# Altium schematic sheet Spectre-SCH_SH2_(rev).SchDoc
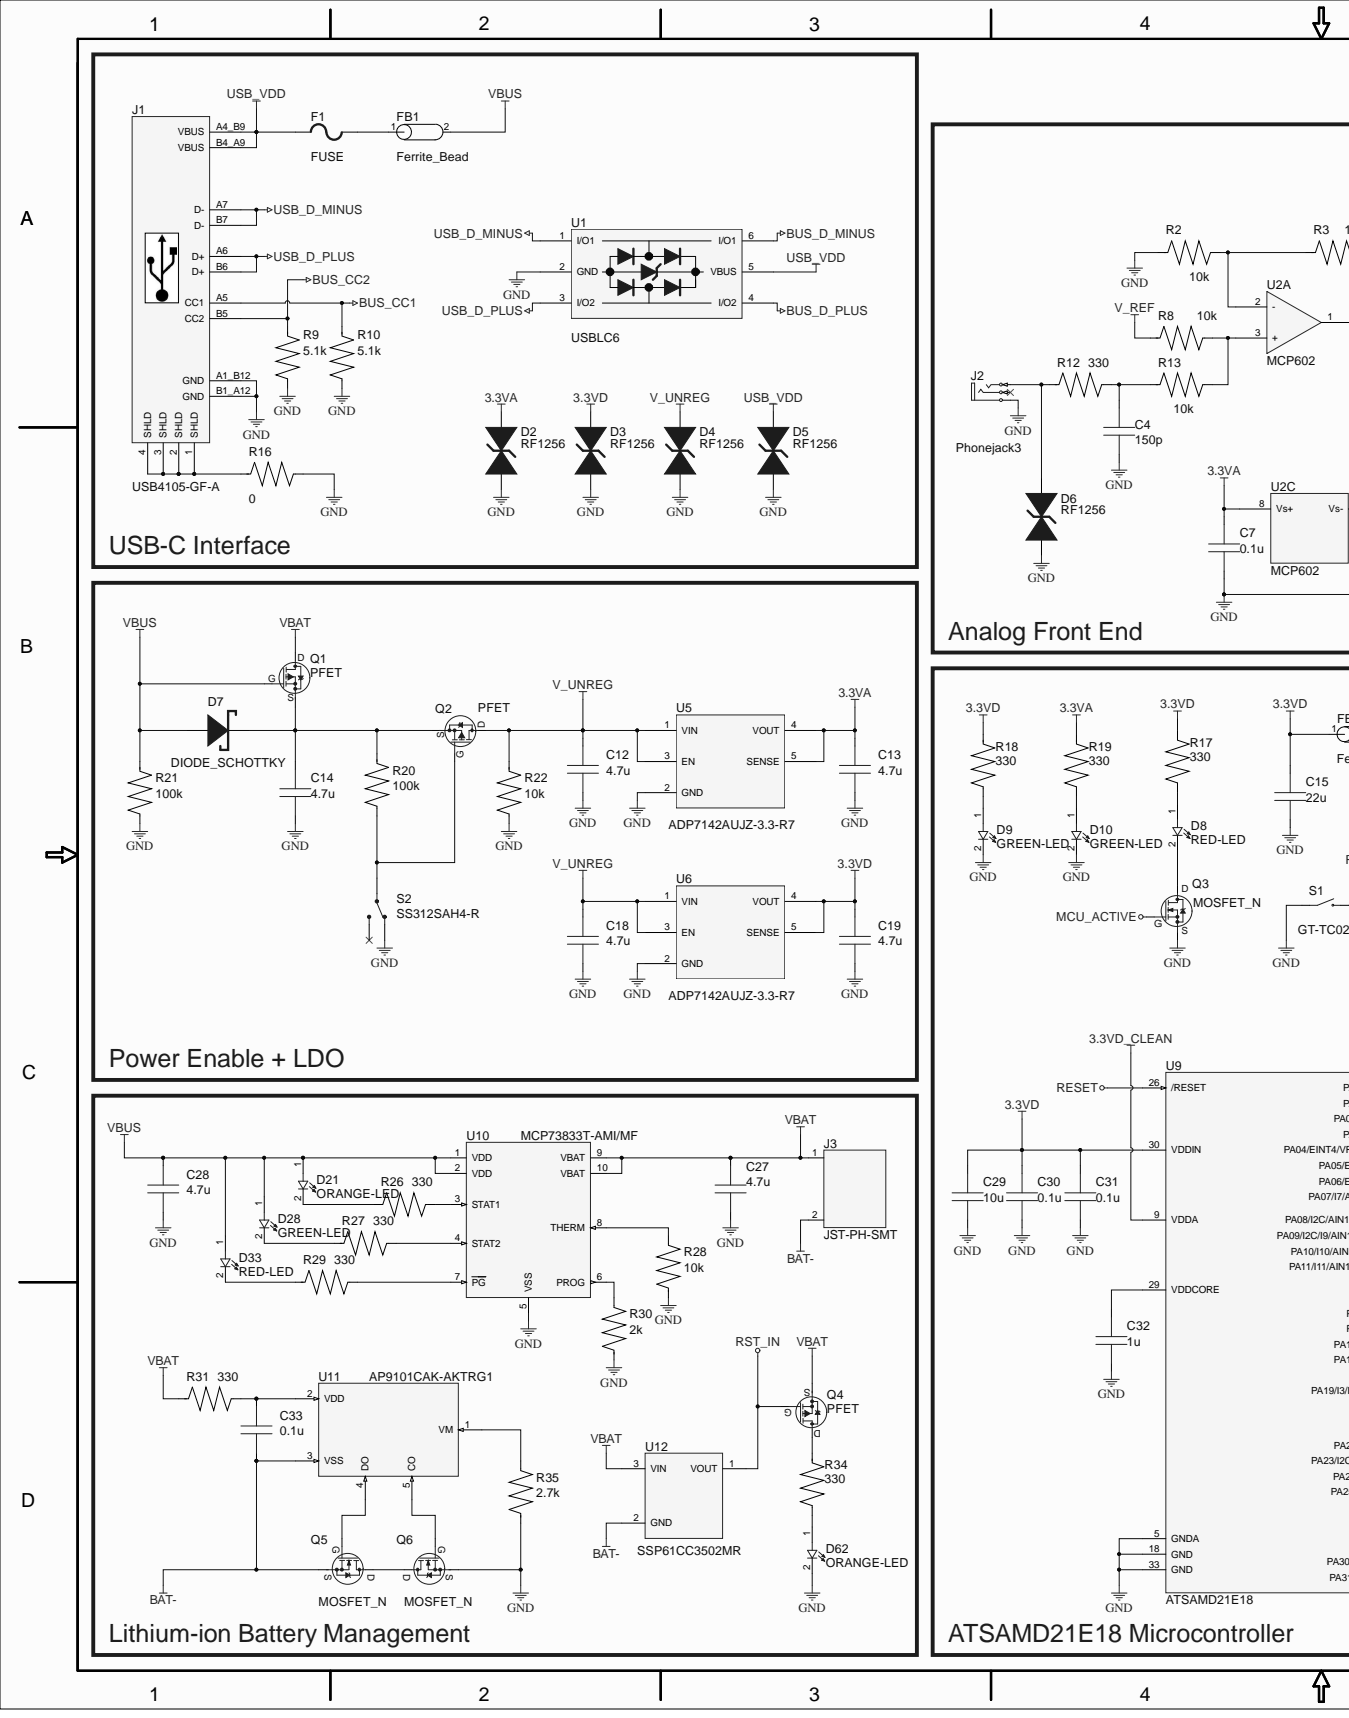
[diagram p0: Spectre-SCH_SH2_(rev).SchDoc, left]
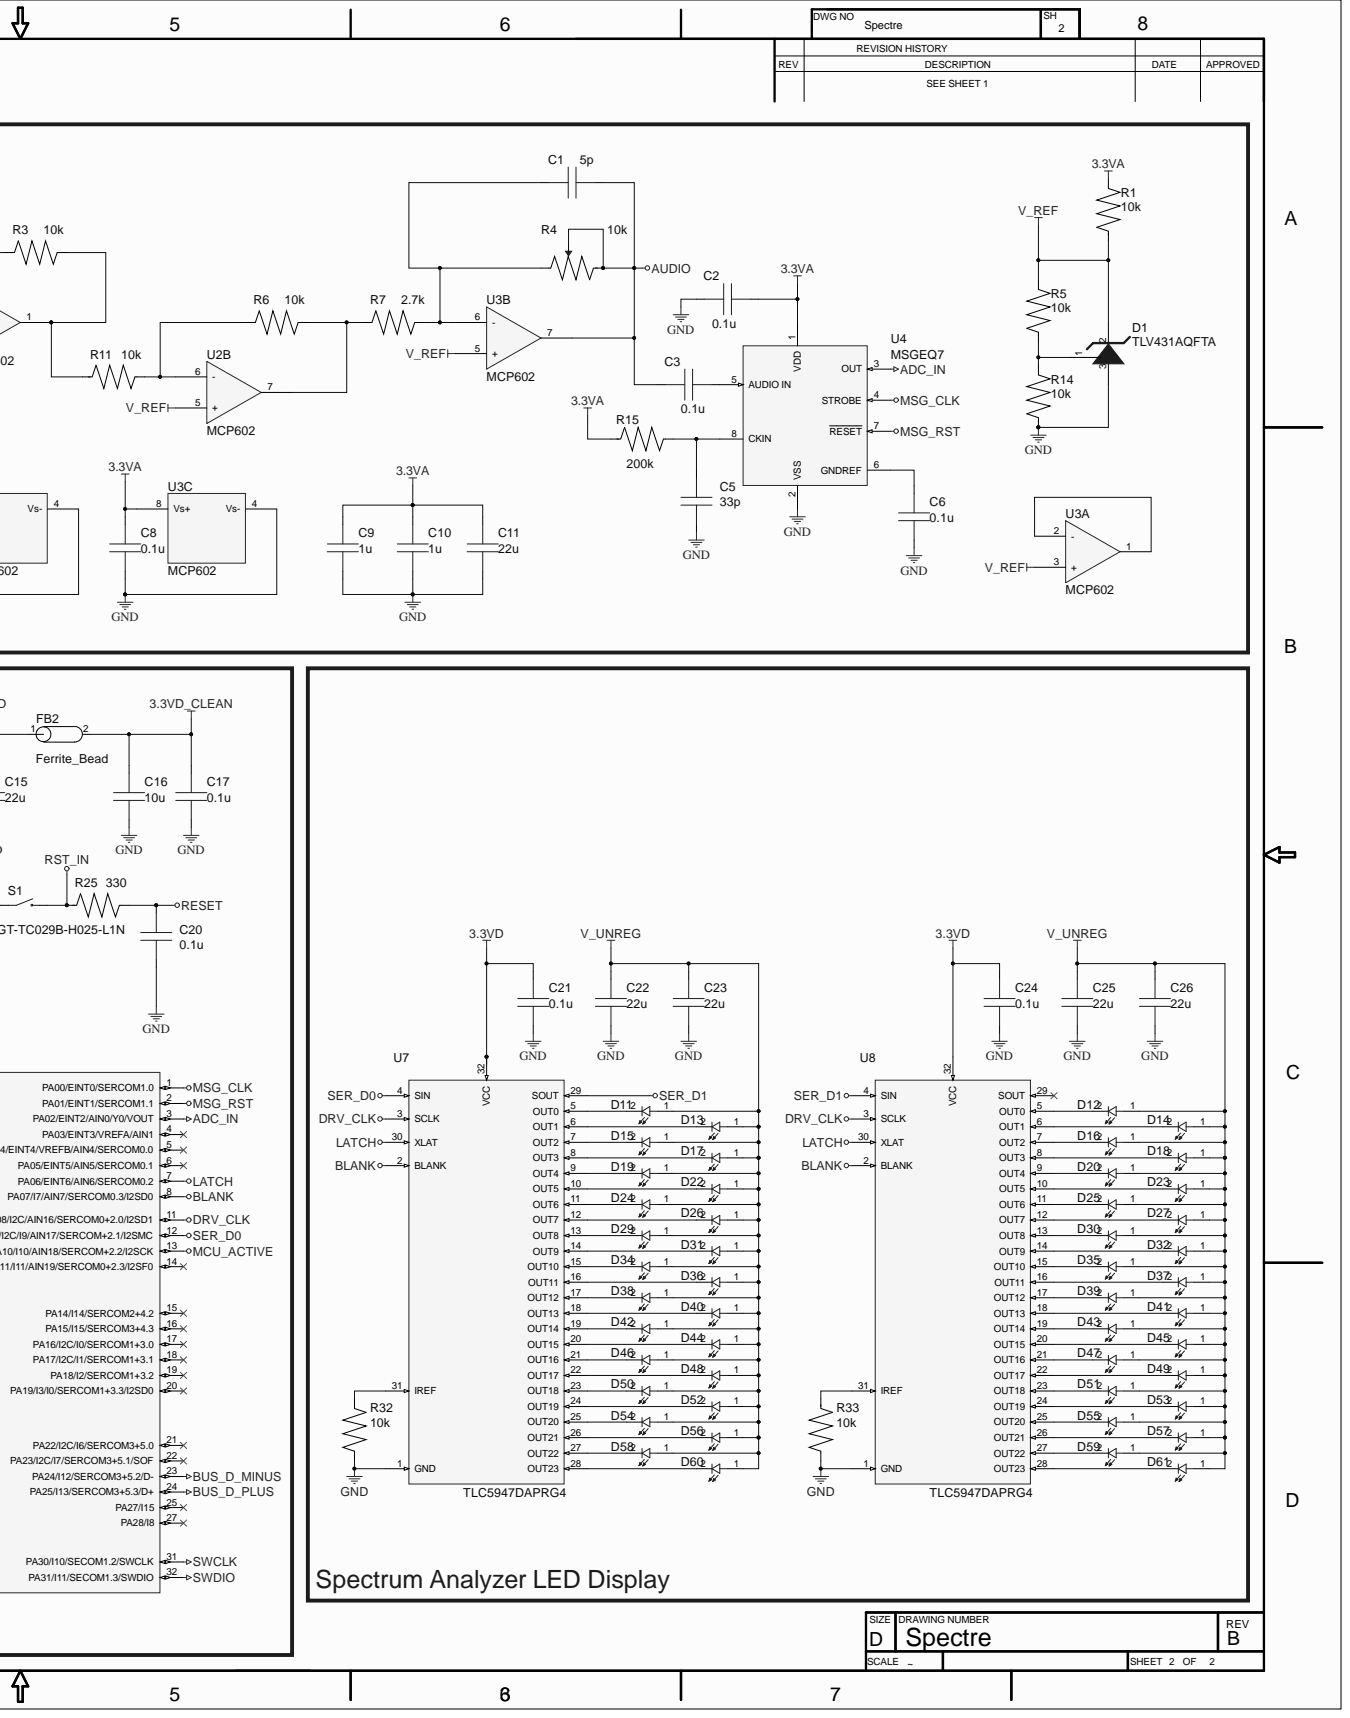
[diagram p1: Spectre-SCH_SH2_(rev).SchDoc, right]

## Parts
C1  5p  [0603]
C2  0.1u  [0603]
C3  0.1u  [0603]
C4  150p  [0603]
C5  33p  [0603]
C6  0.1u  [0603]
C7  0.1u  [0603]
C8  0.1u  [0603]
C9  1u  [0603]
C10  1u  [0603]
C11  22u  [0603]
C12  4.7u  [0603]
C13  4.7u  [0603]
C14  4.7u  [0603]
C15  22u  [0603]
C16  10u  [0603]
C17  0.1u  [0603]
C18  4.7u  [0603]
C19  4.7u  [0603]
C20  0.1u  [0603]
C21  0.1u  [0603]
C22  22u  [0603]
C23  22u  [0603]
C24  0.1u  [0603]
C25  22u  [0603]
C26  22u  [0603]
C27  4.7u  [0603]
C28  4.7u  [0603]
C29  10u  [0603]
C30  0.1u  [0603]
C31  0.1u  [0603]
C32  1u  [0603]
C33  0.1u  [0603]
D1  TLV431AQFTA  [SOT-23-3]
D2  RF1256  [0603]
D3  RF1256  [0603]
D4  RF1256  [0603]
D5  RF1256  [0603]
D6  RF1256  [0603]
D7  DIODE_SCHOTTKY  [SMA]
D8  RED-LED  [0603 LED]
D9  GREEN-LED  [0603 LED]
D10  GREEN-LED  [0603 LED]
D11  RED-LED  [0603 LED]  (value not drawn)
D12  RED-LED  [0603 LED]  (value not drawn)
D13  ORANGE-LED  [0603 LED]
D14  ORANGE-LED  [0603 LED]
D15  YELLOW-LED  [0603 LED]  (value not drawn)
D16  YELLOW-LED  [0603 LED]  (value not drawn)
D17  YELLOW-LED  [0603 LED]  (value not drawn)
D18  YELLOW-LED  [0603 LED]  (value not drawn)
D19  GREEN-LED  [0603 LED]
D20  GREEN-LED  [0603 LED]
D21  ORANGE-LED  [0603 LED]
D22  GREEN-LED  [0603 LED]
D23  GREEN-LED  [0603 LED]
D24  RED-LED  [0603 LED]  (value not drawn)
D25  RED-LED  [0603 LED]  (value not drawn)
D26  ORANGE-LED  [0603 LED]
D27  ORANGE-LED  [0603 LED]
D28  GREEN-LED  [0603 LED]
D29  YELLOW-LED  [0603 LED]  (value not drawn)
D30  YELLOW-LED  [0603 LED]  (value not drawn)
D31  YELLOW-LED  [0603 LED]  (value not drawn)
D32  YELLOW-LED  [0603 LED]  (value not drawn)
D33  RED-LED  [0603 LED]
D34  GREEN-LED  [0603 LED]
D35  GREEN-LED  [0603 LED]
D36  GREEN-LED  [0603 LED]
D37  GREEN-LED  [0603 LED]
D38  GREEN-LED  [0603 LED]
D39  RED-LED  [0603 LED]  (value not drawn)
D40  GREEN-LED  [0603 LED]
D41  ORANGE-LED  [0603 LED]
D42  YELLOW-LED  [0603 LED]  (value not drawn)
D43  YELLOW-LED  [0603 LED]  (value not drawn)
D44  YELLOW-LED  [0603 LED]  (value not drawn)
D45  YELLOW-LED  [0603 LED]  (value not drawn)
D46  ORANGE-LED  [0603 LED]
D47  GREEN-LED  [0603 LED]
D48  RED-LED  [0603 LED]  (value not drawn)
D49  GREEN-LED  [0603 LED]
D50  GREEN-LED  [0603 LED]
D51  GREEN-LED  [0603 LED]
D52  GREEN-LED  [0603 LED]
D53  GREEN-LED  [0603 LED]
D54  YELLOW-LED  [0603 LED]  (value not drawn)
D55  YELLOW-LED  [0603 LED]  (value not drawn)
D56  RED-LED  [0603 LED]  (value not drawn)
D57  YELLOW-LED  [0603 LED]  (value not drawn)
D58  ORANGE-LED  [0603 LED]
D59  ORANGE-LED  [0603 LED]
D60  YELLOW-LED  [0603 LED]  (value not drawn)
D61  RED-LED  [0603 LED]  (value not drawn)
D62  ORANGE-LED  [0603 LED]
F1  FUSE  [1206]
FB1  Ferrite_Bead  [0603]
FB2  Ferrite_Bead  [0603]
J1  USB4105-GF-A  [USB4105-GF-A]
J2  Phonejack3  [STX-3500-3NTR]
J3  JST-PH-SMT  [JST-PH-SMT]
Q1  PFET  [TO-252-3]
Q2  PFET  [TO-252-3]
Q3  MOSFET_N  [SOT-23]
Q4  PFET  [SOT-23]
Q5  MOSFET_N  [SOT-23]
Q6  MOSFET_N  [SOT-23]
R1  10k  [0603]
R2  10k  [0603]
R3  10k  [0603]
R4  10k  [ALPS 9mm]
R5  10k  [0603]
R6  10k  [0603]
R7  2.7k  [0603]
R8  10k  [0603]
R9  5.1k  [0603]
R10  5.1k  [0603]
R11  10k  [0603]
R12  330  [0603]
R13  10k  [0603]
R14  10k  [0603]
R15  200k  [0603]
R16  0  [0603]
R17  330  [0603]
R18  330  [0603]
R19  330  [0603]
R20  100k  [0603]
R21  100k  [0603]
R22  10k  [0603]
R25  330  [0603]
R26  330  [0603]
R27  330  [0603]
R28  10k  [0603]
R29  330  [0603]  (value not drawn)
R30  2k  [0603]
R31  330  [0603]
R32  10k  [0603]
R33  10k  [0603]
R34  330  [0603]
R35  2.7k  [0603]
S1  GT-TC029B-H025-L1N  [GT-TC029B-H025-L1N]
S2  SS312SAH4-R  [SW_SS312SAH4-R]
U1  USBLC6  [SOT-23-6_N]
U2  MCP602  [MSOP-8]  (parts A,B,C)
U3  MCP602  [MSOP-8]  (parts A,B,C)
U4  MSGEQ7  [MSGEQ7]
U5  ADP7142AUJZ-3.3-R7  [TSOT-23-5]
U6  ADP7142AUJZ-3.3-R7  [TSOT-23-5]
U7  TLC5947DAPRG4  [*SOP65P810X120-32N]
U8  TLC5947DAPRG4  [*SOP65P810X120-32N]
U9  ATSAMD21E18  [QFN-32 Handsolder]
U10  MCP73833T-AMI/MF  [MSOP-10]
U11  AP9101CAK-AKTRG1  [SOT-23-5]
U12  SSP61CC3502MR  [SOT-23-3]

## Nets
3.3VA: C2.1 C7.2 C8.2 C9.2 C10.2 C11.2 C13.2 D2.2 R1.1 R15.2 R19.2 U2.8(Vs+) U3.8(Vs+) U4.1(VDD) U5.4(VOUT) U5.5(SENSE)
3.3VD: C15.2 C19.2 C21.2 C24.2 C29.2 C30.2 C31.2 D3.2 FB2.1 R17.2 R18.2 U6.4(VOUT) U6.5(SENSE) U7.32(VCC) U8.32(VCC) U9.30(VDDIN)
3.3VD_CLEAN: C16.2 C17.2 FB2.2 U9.9(VDDA)
ADC_IN: U4.3(OUT) U9.3(PA02/EINT2/AIN0/Y0/VOUT)
AUDIO: C1.1 C3.2 R4.2 R4.3 U3.7
BAT-: C33.1 J3.2 Q5.3 U11.3(VSS) U12.2
BLANK: U7.2(BLANK) U8.2(BLANK) U9.8(PA07/I7/AIN7/SERCOM0.3/I2SD0)
BUS_CC1: J1.A5(CC1) R10.2
BUS_CC2: J1.B5(CC2) R9.2
BUS_D_MINUS: U1.6(I/O1) U9.23(PA24/I12/SERCOM3+5.2/D-)
BUS_D_PLUS: U1.4(I/O2) U9.24(PA25/I13/SERCOM3+5.3/D+)
DRV_CLK: U7.3(SCLK) U8.3(SCLK) U9.11(PA08/I2C/AIN16/SERCOM0+2.0/I2SD1)
GND: C2.2 C4.1 C5.2 C6.2 C7.1 C8.1 C9.1 C10.1 C11.1 C12.1 C13.1 C14.1 C15.1 C16.1 C17.1 C18.1 C19.1 C20.1 C21.1 C22.1 C23.1 C24.1 C25.1 C26.1 C27.1 C28.1 C29.1 C30.1 C31.1 C32.1 D1.3 D2.1 D3.1 D4.1 D5.1 D6.1 D9.2 D10.2 D62.2 J1.A1_B12(GND) J1.B1_A12(GND) J2.1 Q3.3 Q6.3 R2.2 R9.1 R10.1 R14.2 R16.1 R21.1 R22.1 R28.2 R30.2 R32.1 R33.1 R35.2 S1.1 S2.1 U1.2(GND) U2.4(Vs-) U3.4(Vs-) U4.2(VSS) U5.2(GND) U6.2(GND) U7.1(GND) U8.1(GND) U9.5 U9.18 U9.33(GND) U10.5(VSS)
LATCH: U7.30(XLAT) U8.30(XLAT) U9.7(PA06/EINT6/AIN6/SERCOM0.2)
MCU_ACTIVE: Q3.1 U9.13(PA10/I10/AIN18/SERCOM+2.2/I2SCK)
MSG_CLK: U4.4(STROBE) U9.1(PA00/EINT0/SERCOM1.0)
MSG_RST: U4.7(RESET) U9.2(PA01/EINT1/SERCOM1.1)
RESET: C20.2 R25.1 U9.26(/RESET)
RST_IN: Q4.1 R25.2 S1.2 U12.1
SER_D0: U7.4(SIN) U9.12(PA09/I2C/I9/AIN17/SERCOM+2.1/I2SMC)
SER_D1: U7.29(SOUT) U8.4(SIN)
SWCLK: U9.31(PA30/I10/SECOM1.2/SWCLK)
SWDIO: U9.32(PA31/I11/SECOM1.3/SWDIO)
USB_D_MINUS: J1.A7(D-) J1.B7(D-) U1.1(I/O1)
USB_D_PLUS: J1.A6(D+) J1.B6(D+) U1.3(I/O2)
USB_VDD: D5.2 F1.1 J1.A4_B9(VBUS) J1.B4_A9(VBUS) U1.5(VBUS)
V_REF: D1.2 R1.2 R5.1 R8.2 U2.5(+) U3.3(+) U3.5(+)
V_UNREG: C12.2 C18.2 C22.2 C23.2 C25.2 C26.2 D4.2 D11.1 D12.1 D13.1 D14.1 D15.1 D16.1 D17.1 D18.1 D19.1 D20.1 D22.1 D23.1 D24.1 D25.1 D26.1 D27.1 D29.1 D30.1 D31.1 D32.1 D34.1 D35.1 D36.1 D37.1 D38.1 D39.1 D40.1 D41.1 D42.1 D43.1 D44.1 D45.1 D46.1 D47.1 D48.1 D49.1 D50.1 D51.1 D52.1 D53.1 D54.1 D55.1 D56.1 D57.1 D58.1 D59.1 D60.1 D61.1 Q2.2 R22.2 U5.1(VIN) U5.3(EN) U6.1(VIN) U6.3(EN)
VBAT: C27.2 J3.1 Q1.2 Q4.3 R31.2 U10.9(VBAT) U10.10(VBAT) U12.3
VBUS: C28.2 D7.1 D21.1 D28.1 D33.1 FB1.2 Q1.1 R21.2 U10.1(VDD) U10.2(VDD)
NetC1_2: C1.2 R4.1 R7.1 U3.6(-)
NetC3_1: C3.1 U4.5(AUDIO_IN)
NetC4_2: C4.2 R12.1 R13.2
NetC5_1: C5.1 R15.1 U4.8(CKIN)
NetC6_1: C6.1 U4.6(GNDREF)
NetC14_2: C14.2 D7.2 Q1.3 Q2.3 R20.2
NetC32_2: C32.2 U9.29(VDDCORE)
NetC33_2: C33.2 R31.1 U11.2(VDD)
NetD1_1: D1.1 R5.2 R14.1
NetD6_2: D6.2 J2.3 R12.2
NetD8_1: D8.1 R17.1
NetD8_2: D8.2 Q3.2
NetD9_1: D9.1 R18.1
NetD10_1: D10.1 R19.1
NetD11_2: D11.2 U7.5(OUT0)
NetD12_2: D12.2 U8.5(OUT0)
NetD13_2: D13.2 U7.6(OUT1)
NetD14_2: D14.2 U8.6(OUT1)
NetD15_2: D15.2 U7.7(OUT2)
NetD16_2: D16.2 U8.7(OUT2)
NetD17_2: D17.2 U7.8(OUT3)
NetD18_2: D18.2 U8.8(OUT3)
NetD19_2: D19.2 U7.9(OUT4)
NetD20_2: D20.2 U8.9(OUT4)
NetD21_2: D21.2 R26.2
NetD22_2: D22.2 U7.10(OUT5)
NetD23_2: D23.2 U8.10(OUT5)
NetD24_2: D24.2 U7.11(OUT6)
NetD25_2: D25.2 U8.11(OUT6)
NetD26_2: D26.2 U7.12(OUT7)
NetD27_2: D27.2 U8.12(OUT7)
NetD28_2: D28.2 R27.2
NetD29_2: D29.2 U7.13(OUT8)
NetD30_2: D30.2 U8.13(OUT8)
NetD31_2: D31.2 U7.14(OUT9)
NetD32_2: D32.2 U8.14(OUT9)
NetD33_2: D33.2 R29.2
NetD34_2: D34.2 U7.15(OUT10)
NetD35_2: D35.2 U8.15(OUT10)
NetD36_2: D36.2 U7.16(OUT11)
NetD37_2: D37.2 U8.16(OUT11)
NetD38_2: D38.2 U7.17(OUT12)
NetD39_2: D39.2 U8.17(OUT12)
NetD40_2: D40.2 U7.18(OUT13)
NetD41_2: D41.2 U8.18(OUT13)
NetD42_2: D42.2 U7.19(OUT14)
NetD43_2: D43.2 U8.19(OUT14)
NetD44_2: D44.2 U7.20(OUT15)
NetD45_2: D45.2 U8.20(OUT15)
NetD46_2: D46.2 U7.21(OUT16)
NetD47_2: D47.2 U8.21(OUT16)
NetD48_2: D48.2 U7.22(OUT17)
NetD49_2: D49.2 U8.22(OUT17)
NetD50_2: D50.2 U7.23(OUT18)
NetD51_2: D51.2 U8.23(OUT18)
NetD52_2: D52.2 U7.24(OUT19)
NetD53_2: D53.2 U8.24(OUT19)
NetD54_2: D54.2 U7.25(OUT20)
NetD55_2: D55.2 U8.25(OUT20)
NetD56_2: D56.2 U7.26(OUT21)
NetD57_2: D57.2 U8.26(OUT21)
NetD58_2: D58.2 U7.27(OUT22)
NetD59_2: D59.2 U8.27(OUT22)
NetD60_2: D60.2 U7.28(OUT23)
NetD61_2: D61.2 U8.28(OUT23)
NetD62_1: D62.1 R34.1
NetF1_2: F1.2 FB1.1
NetJ1_1: J1.1(SHLD) J1.2(SHLD) J1.3(SHLD) J1.4(SHLD) R16.2
NetQ2_1: Q2.1 R20.1 S2.2
NetQ4_2: Q4.2 R34.2
NetQ5_1: Q5.1 U11.4(DO)
NetQ5_2: Q5.2 Q6.2
NetQ6_1: Q6.1 U11.5(CO)
NetR2_1: R2.1 R3.2 U2.2(-)
NetR3_1: R3.1 R11.2 U2.1
NetR6_1: R6.1 R7.2 U2.7
NetR6_2: R6.2 R11.1 U2.6(-)
NetR8_1: R8.1 R13.1 U2.3(+)
NetR26_1: R26.1 U10.3(STAT1)
NetR27_1: R27.1 U10.4(STAT2)
NetR28_1: R28.1 U10.8(THERM)
NetR29_1: R29.1 U10.7(PG)
NetR30_1: R30.1 U10.6(PROG)
NetR32_2: R32.2 U7.31(IREF)
NetR33_2: R33.2 U8.31(IREF)
NetR35_1: R35.1 U11.1(VM)
NetU3_1: U3.1 U3.2(-)

Not connected: J2 2; S2 3; U8 29(SOUT); U9 4(PA03/EINT3/VREFA/AIN1) 6(PA05/EINT5/AIN5/SERCOM0.1) 14(PA11/I11/AIN19/SERCOM0+2.3/I2SF0) 15(PA14/I14/SERCOM2+4.2) 16(PA15/I15/SERCOM3+4.3) 17(PA16/I2C/I0/SERCOM1+3.0) 19(PA18/I2/SERCOM1+3.2) 20(PA19/I3/I0/SERCOM1+3.3/I2SD0) 21(PA22/I2C/I6/SERCOM3+5.0) 22(PA23/I2C/I7/SERCOM3+5.1/SOF) 25(PA27/I15) 27(PA28/I8)

## Library data of the parts
C1-C33 = CAP: Component Kind: Standard; Library Name: CAPACITORS.IntLib
D1 = TLV431AQFTA: Shunt Voltage Reference IC Adjustable 1.24V 18 VV ±1% 15 mA SOT-23-3; Manufacturer: Diodes Incorporated; Component Kind: Standard; Library Name: TLV431AQFTA.IntLib; Part Number: TLV431AQFTA
D2-D6 = DIODE_TVS_BIDIR: TVS DIODE BIDIR; Component Kind: Standard; Library Name: SEMICONDUCTORS.IntLib
D7 = DIODE_SCHOTTKY: DIODE SCHOTTKY; Component Kind: Standard; Library Name: SEMICONDUCTORS.IntLib
D8-D62 = SMT-LED: Component Kind: Standard; Library Name: SMT-LED.IntLib
FB1 FB2 = Ferrite_Bead: Ferrite Bead; Component Kind: Standard; Library Name: Ferrite_Beads.IntLib
J1 = USB4105-GF-A: USB-C (USB TYPE-C) USB 2.0 Receptacle Connector 24 (16+8 Dummy) Position Surface Mount, Right Angle; Through Hole; Manufacturer: GCT; Component Kind: Standard; Library Name: USB4105-GF-A.SchLib; Part Number: USB4105-GF-A
J2 = Phonejack3: Jack Socket, 1/4" [6.5mm], Thru-Hole, Vertical, 3-Conductor Open Circuit (Non-Normalling); DrillAndSlotSize: Corresponds to nominal value.; PackageDocument: 2003; PCB_Layout: Complies with manufacturer's recommendation.; Component Kind: Standard; ComponentLink1Description: Manufacturer Link; ComponentLink1URL: http://www.cliffuk.co.uk/; DatasheetDocument: 2003; LatestRevisionDate: 15-Jul-2004; LatestRevisionNote: Component Replaced.; Library Name: Miscellaneous Connectors.IntLib; PackageReference: S1VS_SSS; Published: 15-Jul-2004; Signal Integrity: JACK Socket; Publisher: Altium Limited
Q1 Q2 Q4 = MOSFET_P: MOSFET, P CH, 3-PIN; Component Kind: Standard; Library Name: SEMICONDUCTORS.IntLib
Q3 Q5 Q6 = MOSFET_N: MOSFET, N CH, 3-PIN; Component Kind: Standard; Library Name: SEMICONDUCTORS.IntLib
R1-R3 R5-R22 R25-R35 = RES: RESISTOR; Component Kind: Standard; Library Name: RESISTORS.IntLib
R4 = Adafruit 356: 10 kOhms - PC Pin Through Hole Trimmer Potentiometer - Turn Top Adjustment; Manufacturer: Adafruit Industries LLC; Part Number: 356; Component Kind: Standard; Library Name: ADAFRUIT-10K.IntLib
S2 = SS312SAH4-R: Slide Switch SPDT Surface Mount, Right Angle; Manufacturer: NKK Switches; Component Kind: Standard; Library Name: SS312SAH4-R.IntLib; Part Number: SS312SAH4-R
U1 = USBLC6-2SC6: IC ESD PROTECTION LO CAP SOT23-6; Manufacturer: STMicroelectronics; Component Kind: Standard; Library Name: SEMICONDUCTORS.IntLib; Part Number: USBLC6-2SC6
U2 U3 = AD8072: IC OPAMP VIDEO DUAL 8MSOP; Manufacturer: Analog Devices Inc.; Part Number: AD8072ARMZ; Component Kind: Standard; Library Name: Op_Amps.IntLib
U10 = MCP73833T-AMI/MF: Charger IC Lithium Ion/Polymer 10-DFN _3x3_; MF: Microchip; Availability: Unavailable; Component Kind: Standard; Library Name: MCP73833.SchLib; MP: MCP73833T-AMI/MF; Package: DFN-10 Microchip; Price: None; SnapEDA_Link: https://www.snapeda.com/parts/MCP73833T-AMI/MF/Microchip/view-part/1192592/?ref=snap
U12 = SSP61C: Component Kind: Standard; Library Name: SSP61C.IntLib
F1 = FUSE: Component Kind: Standard; Description: FUSE; Library Name: Power.IntLib
J3 = JST-PH-SMT: Component Kind: Standard; Library Name: JST-PH-SMT.IntLib
S1 = GT-TC029B-H025-L1N: Component Kind: Standard; Library Name: GT-TC029B-H025-L1N.IntLib
U4 = MSGEQ7: Component Kind: Standard; Library Name: MSGEQ7.IntLib
U5 U6 = ADP7142AUJZ-3.3-R7: Component Kind: Standard; Library Name: ADP7142AUJZ-3.3-R7.IntLib
U7 U8 = TLC5947DAPRG4: Component Kind: Standard; Library Name: TLC5947DAPRG4.IntLib
U9 = ATSAMD21E18: Component Kind: Standard; Library Name: ATSAMD21E18.IntLib
U11 = AP9101CAK-AKTRG1: Component Kind: Standard; Library Name: AP9101CAK-AKTRG1.IntLib
